# Altium schematic sheet PowerSupply.SchDoc(PowerSupply)
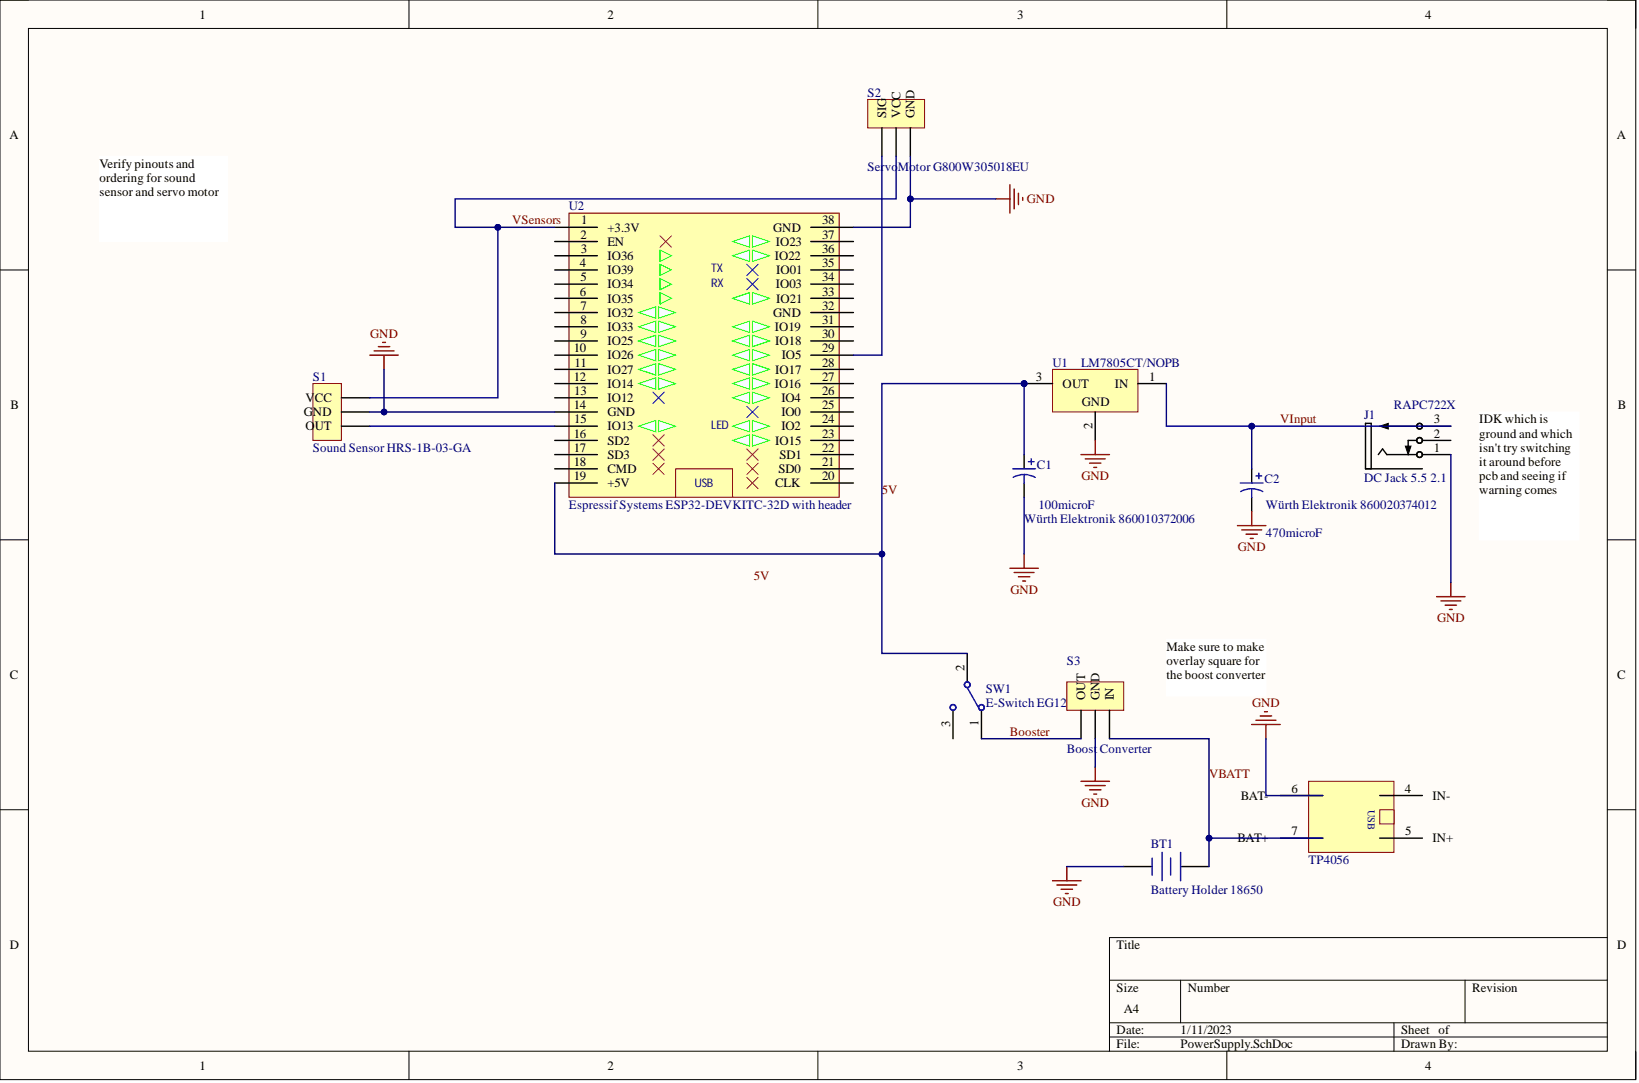
[diagram p0: PowerSupply.SchDoc]

## Parts
BT1  Battery Holder 18650  [PCB-3u15nw3mkqoh4cvtggw4-1]
C1  Würth Elektronik 860010372006  [PCB-kyskzfzvu5hkft7entwf-2]
C2  Würth Elektronik 860020374012  [PCB-3azp1z6rt2bm1haulf0v-1]
J1  DC Jack 5.5 2.1  Manufacturer Part Number: RAPC722X  [PCB-gxnxm3n04w37egsept5y-1]
S1  Sound Sensor HRS-1B-03-GA
S2  ServoMotor G800W305018EU
S3  Boost Converter
SW1  E-Switch EG1218
U1  LM7805CT/NOPB
U2  Espressif Systems ESP32-DEVKITC-32D with header  Manufacturer Part Number: ESP32-DEVKITC-32D  [PCB-v7o6mlcnvy9ltvisia1k-2]
  TP4056

## Nets
GND: BT1.2 C1.2 C2.2 J1.1 S1.2 S2.1 S3.2 U1.2 U2.14(GND) U2.38(GND) .6
Net_4: .4
Net_5: .5
5V: C1.1 SW1.2 U1.3 U2.19(+5V)
Booster: S3.1 SW1.1
VBATT: BT1.1 S3.3 .7
VInput: C2.1 J1.3 U1.1
VSensors: S1.3 S2.2 U2.1(+3.3V)
NetS1_1: S1.1 U2.15(IO13)
NetS2_3: S2.3 U2.29(IO5)

Not connected: J1 2; SW1 3; U2 2(EN) 3(IO36) 4(IO39) 5(IO34) 6(IO35) 7(IO32) 8(IO33) 9(IO25) 10(IO26) 11(IO27) 12(IO14) 13(IO12) 16(SD2) 17(SD3) 18(CMD) 20(CLK) 21(SD0) 22(SD1) 23(IO15) 24(IO2) 25(IO0) 26(IO4) 27(IO16) 28(IO17) 30(IO18) 31(IO19) 32(GND) 33(IO21) 34(IO03) 35(IO01) 36(IO22) 37(IO23)

## Library data of the parts
BT1 = CMP-40d98835f05c9f1e-4: Pcb Holder Thm 18650 Polarized; Manufacturer Part Number: 1043P; Component Kind: Standard; Library Name: My Personal Space; Lifecycle Status: Not Listed by Manufacturer; Octopart URL: http://octopart.com/1043p-keystone-31888867; OctopartID: 40d98835f05c9f1e
C1 = CMP-7bd7b1e54b20a410-1: Aluminium Electrolytic Capacitor, WCAP-ATG8 Series, 100 F, 20%, 16 V, 5 mm, Radial Leaded; Capacitance: 100 µF; Voltage Rating (DC): 16.0 V; Capacitance Tolerance: ±20%; Component Kind: Standard; Library Name: My Personal Space; Manufacturer Part Number: 860010372006; Octopart URL: http://octopart.com/860010372006-w%C3%BCrth+elektronik-54007185; OctopartID: 7bd7b1e54b20a410; REACH SVHC Compliance: No SVHC; RoHS: Compliant; Size-Diameter: 5.00 mm; Size-Height: 11.0 mm
C2 = CMP-e25928656d7f3d9e-1: Al Electrolytic Capacitors 470uF 16V; Component Kind: Standard; Library Name: My Personal Space; Manufacturer Part Number: 860020374012; Mounting Style: Through Hole; Octopart URL: http://octopart.com/860020374012-w%C3%BCrth+elektronik-53914176; OctopartID: e25928656d7f3d9e; Packaging: Tape & Box (TB)
J1 = CMP-da391331d91005fe-17: Conn; AV; Power; Jack; Mini; 2.0mmPinDia; RtAngle; Thru-hole; forS760, S765Plug; Current Rating: 5.0 A; Gender: Female; Lead-Free Status: Lead Free; Mounting Angle: 90.0 °; Number of Contacts: 24; Voltage Rating (AC): 250.0 V; Color: Black; Component Kind: Standard; Contact Plating: Nickel; Library Name: My Personal Space; Mounting Style: Through Hole, PCB, Solder; Octopart URL: http://octopart.com/rapc722x-switchcraft-140879; OctopartID: da391331d91005fe; Packaging: Bulk; REACH SVHC Compliance: No SVHC; RoHS: Compliant
S1-S3 = CMP-45008c3683009ac7-6: Pin header, single-row 90° 3-pin [JST] S3B-XH-A Pin header, single-row 90° 3-pin; Component Kind: Standard; Library Name: My Personal Space
SW1 = CMP-9a5a5340a2f5459d-9: Slide Switch, 500 V, -20 to 70 degC, 3-Pin THD, RoHS; Actuator Type: Standard; Contacts Type: SPDT; Current Rating: 200 mA; Lead-Free Status: Lead Free; Number of Positions: 2; Component Kind: Standard; Contact Material: Copper Alloy; Contact Plating: Silver; DatasheetVersion: Oct-15; Library Name: My Personal Space; Manufacturer Part Number: EG1218; Mounting Style: PCB, Through Hole; Octopart Url: http://octopart.com/eg1218-e-switch-1056201; OctopartID: 9a5a5340a2f5459d; Orientation: Vertical; PackageDescription: 3-Pin Through Hole Device, Body 11.6 x 4 mm, Pitch 2.5 mm; PackageReference: EG1218; Packaging: Bulk; RoHS: Compliant; Seller Landing  Url: https://octopart-clicks.com/click/track?country=US&ak=8157f166&sig=0e9adab&sid=459&ppid=1056201&vpid=1179261&ct=offers; Size-Height: 5.40 mm; Size-Length: 2.03 mm; Size-Width: 4.00 mm
U1 = CMP-8a9e42f854f65559-10: Linear Voltage Regulators 5 Volt Reg [Texas Instruments]; Component Kind: Standard; Library Name: My Personal Space
U2 = CMP-0991c5192c5ed656-39: Eval Board For ESP-WROOM-32 - 38pin module with 8.4mm header; Component Kind: Standard; Library Name: My Personal Space; Octopart URL: https://octopart.com/esp32-devkitc-32d-espressif+systems-92405929; OctopartID: 0991c5192c5ed656
 = CMP-88poo8h67ic0nqpqrd41-1: Lithium Battery Charger; Component Kind: Standard; CustomComponentID: 88poo8h67ic0nqpqrd41; Library Name: My Personal Space
